# Altium schematic sheet A-Headers.SchDoc(A-Headers)
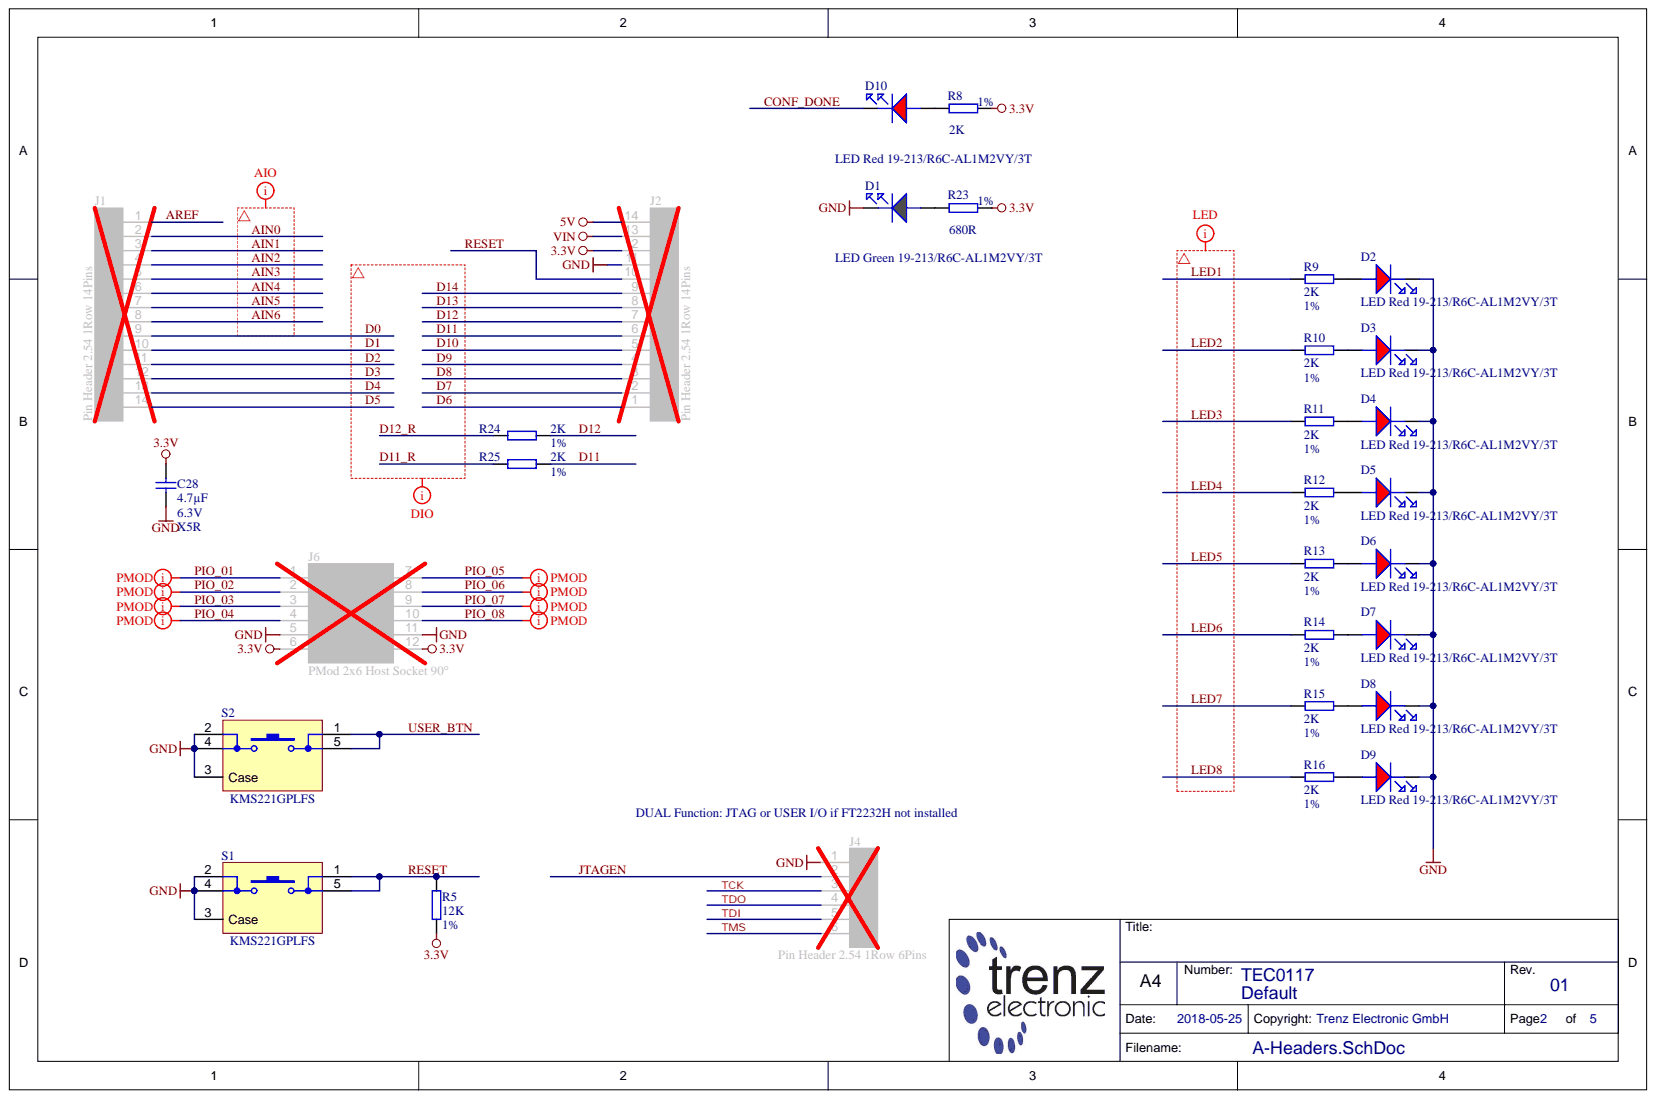
[diagram p0: A-Headers.SchDoc]

## Parts
C28  4.7µF  Technology: X5R  Voltage: 6.3V  [CAPC1005X60N]
D1  LED Green 19-213/R6C-AL1M2VY/3T  [LEDC1608X55N_GREEN]
D2  LED Red 19-213/R6C-AL1M2VY/3T  Database Table Name: LED  H-ART: 19-213/R6C-AL1M2VY/3T  [LEDC1608X55N_RED]
D3  LED Red 19-213/R6C-AL1M2VY/3T  Database Table Name: LED  H-ART: 19-213/R6C-AL1M2VY/3T  [LEDC1608X55N_RED]
D4  LED Red 19-213/R6C-AL1M2VY/3T  Database Table Name: LED  H-ART: 19-213/R6C-AL1M2VY/3T  [LEDC1608X55N_RED]
D5  LED Red 19-213/R6C-AL1M2VY/3T  Database Table Name: LED  H-ART: 19-213/R6C-AL1M2VY/3T  [LEDC1608X55N_RED]
D6  LED Red 19-213/R6C-AL1M2VY/3T  Database Table Name: LED  H-ART: 19-213/R6C-AL1M2VY/3T  [LEDC1608X55N_RED]
D7  LED Red 19-213/R6C-AL1M2VY/3T  Database Table Name: LED  H-ART: 19-213/R6C-AL1M2VY/3T  [LEDC1608X55N_RED]
D8  LED Red 19-213/R6C-AL1M2VY/3T  Database Table Name: LED  H-ART: 19-213/R6C-AL1M2VY/3T  [LEDC1608X55N_RED]
D9  LED Red 19-213/R6C-AL1M2VY/3T  Database Table Name: LED  H-ART: 19-213/R6C-AL1M2VY/3T  [LEDC1608X55N_RED]
D10  LED Red 19-213/R6C-AL1M2VY/3T  [LEDC1608X55N_RED]
J1  Pin Header 2.54 1Row 14Pins  [STIFTLEISTE2_54 OG 1R-14]
J2  Pin Header 2.54 1Row 14Pins  [STIFTLEISTE2_54 OG 1R-14]
J4  Pin Header 2.54 1Row 6Pins  [STIFTLEISTE2_54 OG 1R-6]
J6  PMod 2x6 Host Socket 90°  [PMod2x6]
R5  12K  Tolerance: 1%  [RESC1005X40N]
R8  2K  Tolerance: 1%  [RESC1005X40N]
R9  2K  Tolerance: 1%  [RESC1005X40N]
R10  2K  Tolerance: 1%  [RESC1005X40N]
R11  2K  Tolerance: 1%  [RESC1005X40N]
R12  2K  Tolerance: 1%  [RESC1005X40N]
R13  2K  Tolerance: 1%  [RESC1005X40N]
R14  2K  Tolerance: 1%  [RESC1005X40N]
R15  2K  Tolerance: 1%  [RESC1005X40N]
R16  2K  Tolerance: 1%  [RESC1005X40N]
R23  680R  Tolerance: 1%  [RESC1005X40N]
R24  2K  Tolerance: 1%  [RESC1005X40N]
R25  2K  Tolerance: 1%  [RESC1005X40N]
S1  KMS221GPLFS  [KMS2xxGPLFS]
S2  KMS221GPLFS  [KMS2xxGPLFS]

## Nets
3.3V: C28.1 J2.12 J6.6(VCC) J6.12(VCC) R5.2 R8.2 R23.2
5V: J2.14
GND: C28.2 D1.K D2.K D3.K D4.K D5.K D6.K D7.K D8.K D9.K J2.11 J4.1 J6.5(GND) J6.11(GND) S1.2 S1.3(Case) S1.4 S2.2 S2.3(Case) S2.4
VIN: J2.13
AIN0: J1.2
AIN1: J1.3
AIN2: J1.4
AIN3: J1.5
AIN4: J1.6
AIN5: J1.7
AIN6: J1.8
AREF: J1.1
CONF_DONE: D10.K
D0: J1.9
D1: J1.10
D2: J1.11
D3: J1.12
D4: J1.13
D5: J1.14
D6: J2.1
D7: J2.2
D8: J2.3
D9: J2.4
D10: J2.5
D11: J2.6 R25.1
D11_R: R25.2
D12: J2.7 R24.1
D12_R: R24.2
D13: J2.8
D14: J2.9
JTAGEN: J4.2
LED1: R9.1
LED2: R10.1
LED3: R11.1
LED4: R12.1
LED5: R13.1
LED6: R14.1
LED7: R15.1
LED8: R16.1
PIO_01: J6.1
PIO_02: J6.2
PIO_03: J6.3
PIO_04: J6.4
PIO_05: J6.7
PIO_06: J6.8
PIO_07: J6.9
PIO_08: J6.10
RESET: J2.10 R5.1 S1.1 S1.5
TCK: J4.3
TDI: J4.5
TDO: J4.4
TMS: J4.6
USER_BTN: S2.1 S2.5
NetD1_A: D1.A R23.1
NetD2_A: D2.A R9.2
NetD3_A: D3.A R10.2
NetD4_A: D4.A R11.2
NetD5_A: D5.A R12.2
NetD6_A: D6.A R13.2
NetD7_A: D7.A R14.2
NetD8_A: D8.A R15.2
NetD9_A: D9.A R16.2
NetD10_A: D10.A R8.1
N00039:
N00040:
N00041:

## Library data of the parts
C28 = 24719: KON 4.7µF 6.3V X5R 20% SMD BF 1005 (0402); Database Table Name: C_1005_0402; Mixed: SMT; Preferred: Yes; Temperature-Range: -55/+85; Value: 4.7u; AN: 24719; Case: 1005 (0402); Component Kind: Standard; Library Name: TE.DbLib; Tolerance: 20%
D1 = 28262: Chip LED 0603 Briliant Green; H-ART: 19-213/G6C-BM1N2/DT; Mixed: SMT; Temperature-Range: -40/+85 (Abs); AN: 28262; Case: 1608 (0603); Component Kind: Standard; Database Table Name: LED; Library Name: TE.DbLib; HelpURL: b:\AD\adlib\datasheet\19-213-G6C-BM1\
D2-D10 = 28261: Chip LED 0603 Briliant Red; Mixed: SMT; AN: 28261; Case: 1608 (0603); Component Kind: Standard; Library Name: TE.DbLib; HelpURL: b:\AD\adlib\datasheet\19-213-R6C\
  D10: Database Table Name: LED; H-ART: 19-213/R6C-AL1M2VY/3T
J1 J2 = 27961: Stiftleiste gerade 1-reihig, 14pol.; Database Table Name: CON_HEADER; Mixed: THT; AN: 27961; Component Kind: Standard; Library Name: TE.DbLib; HelpURL: b:\AD\adlib\datasheet\W+P_943_944_945\
J4 = 28042: Stiftleiste gerade 1-reihig, 6pol; Database Table Name: CON_HEADER; Mixed: THT; AN: 28042; Component Kind: Standard; Library Name: TE.DbLib
J6 = 25215: PMod 2x6 Socket 90°; Database Table Name: CON_IO; Mixed: THT; Trenz-Article-Number: 23185; Trenz-Stock: Yes; AN: 25215; Component Kind: Standard; Library Name: TE.DbLib; HelpURL: b:\ad\adlib\datasheet\6130xx243121\
R5 = 22598: WID SMD 12K 1% 0,063W  BF 1005 (0402); Database Table Name: R_1005_0402; Mixed: SMT; Preferred: Yes; AN: 22598; Case: 1005 (0402); Component Kind: Standard; Library Name: TE.DbLib; Technology: TK100; Value: 12K
R8-R16 R24 R25 = 25073: WID SMD 2K 1% 0,063W  BF 1005 (0402); Database Table Name: R_1005_0402; H-ART: RC0402FR-072KL; Mixed: SMT; Preferred: Yes; AN: 25073; Case: 1005 (0402); Component Kind: Standard; Library Name: TE.DbLib; Technology: TK100; Value: 2K
R23 = 22761: WID SMD 680R 1% 0,063W; Database Table Name: R_1005_0402; H-ART: RC0402FR-13680RL; Mixed: SMT; Preferred: Yes; Value: 680; AN: 22761; Case: 1005 (0402); Component Kind: Standard; Library Name: TE.DbLib; Technology: TK100
S1 S2 = 28549: Database Table Name: PB_SW; Mixed: SMT; AN: 28549; Component Kind: Standard; Description: KMS221GPLFS; H-ART: KMS221GPLFS; Library Name: TE.DbLib; HelpURL: B:\AD\adlib\datasheet\KMS
